# Altium schematic sheet SHEET_1.SchDoc
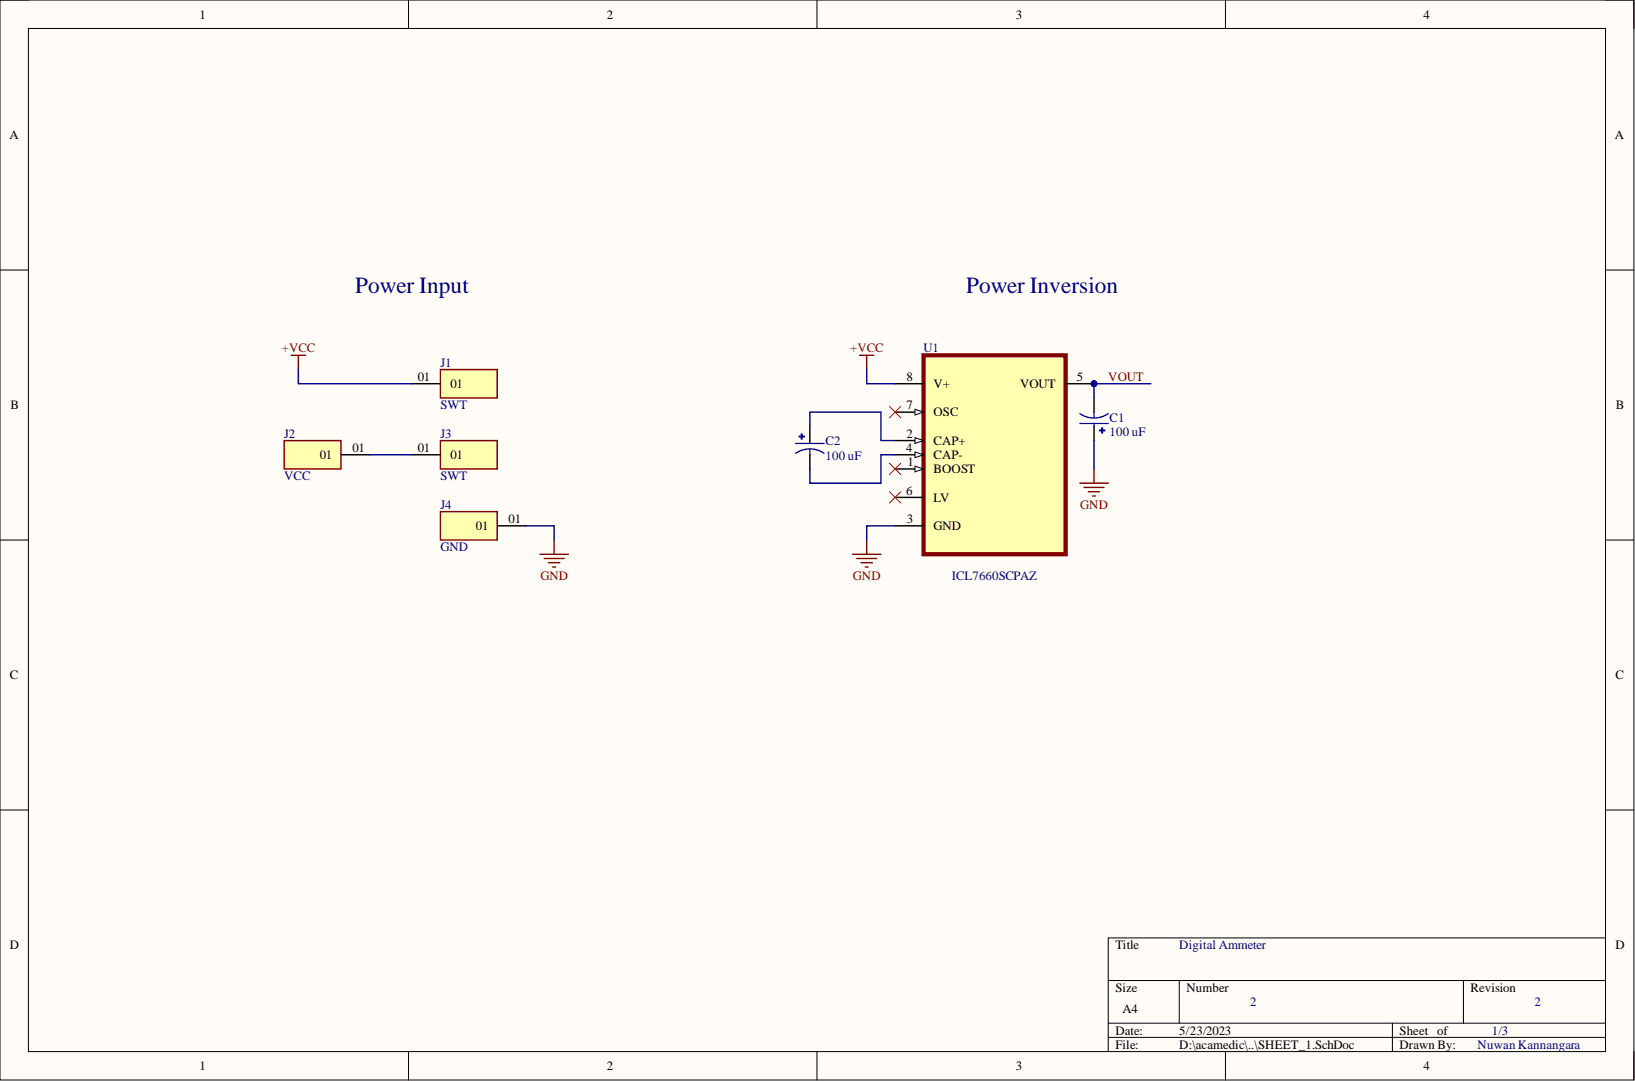
[diagram p0: SHEET_1.SchDoc]

## Parts
C1  100 uF  [CAPPRD500W60D1250H2000]
C2  100 uF  [CAPPRD500W60D1250H2000]
J1  SWT  [SAMTEC_MTMM-101-XX-X-S-XXX]
J2  VCC  [SAMTEC_MTMM-101-XX-X-S-XXX]
J3  SWT  [SAMTEC_MTMM-101-XX-X-S-XXX]
J4  GND  [SAMTEC_MTMM-101-XX-X-S-XXX]
U1  ICL7660SCPAZ  [DIP254P762X533-8]

## Nets
+VCC: J1.01 U1.8(V+)
GND: C1.P J4.01 U1.3(GND)
VOUT: C1.N U1.5(VOUT)
NetC2_N: C2.N U1.4(CAP-)
NetC2_P: C2.P U1.2(CAP+)
NetJ2_01: J2.01 J3.01

Not connected: U1 1(BOOST) 6(LV) 7(OSC)

## Library data of the parts
C1 C2 = ECA2WM100B: CAP ALUM 10UF 20% 450V RADIAL; MF: Panasonic; MP: ECA-2WM100B; Value: $0.77; Availability: In Stock; Check_prices: https://www.snapeda.com/parts/ECA-2WM100B/Panasonic/view-part/2313535/?ref=eda; Component Kind: Standard; Library Name: ECA2WM100B.SchLib; Package: RADIAL-2 Panasonic; Price: None; Purchase-URL: https://pricing.snapeda.com/search/part/ECA-2WM100B/?ref=eda; SnapEDA_Link: https://www.snapeda.com/parts/ECA-2WM100B/Panasonic/view-part/2313535/?ref=snap; Supplier 1: Mouser
J1-J4 = MTMM-101-XX-X-S-XXX: Connector Header position; Availability: Unavailable; Component Kind: Standard; Library Name: MTMM-101-XX-X-S-XXX.SchLib; MP: MTMM-101-XX-X-S-XXX; Package: None; Price: None
U1 = ICL7660SCPAZ: Charge Pump Switching Regulator IC Positive or Negative Fixed -Vin, 2Vin 1 Output 45mA 8-DIP (0.300, 7.62mm); MF: Renesas Electronics America Inc; Value: $2.12; Availability: In Stock; Check_prices: https://www.snapeda.com/parts/ICL7660SCPAZ/Renesas/view-part/?ref=eda; Component Kind: Standard; Library Name: ICL7660SCPAZ.SchLib; MP: ICL7660SCPAZ; Package: DIP-8 Renesas / Intersil; Price: None; Purchase-URL: https://pricing.snapeda.com/search/part/ICL7660SCPAZ/?ref=eda; SnapEDA_Link: https://www.snapeda.com/parts/ICL7660SCPAZ/Renesas/view-part/?ref=snap; Supplier 1: Rs
